# Altium schematic sheet PowerSupply.SchDoc(PowerSupply)
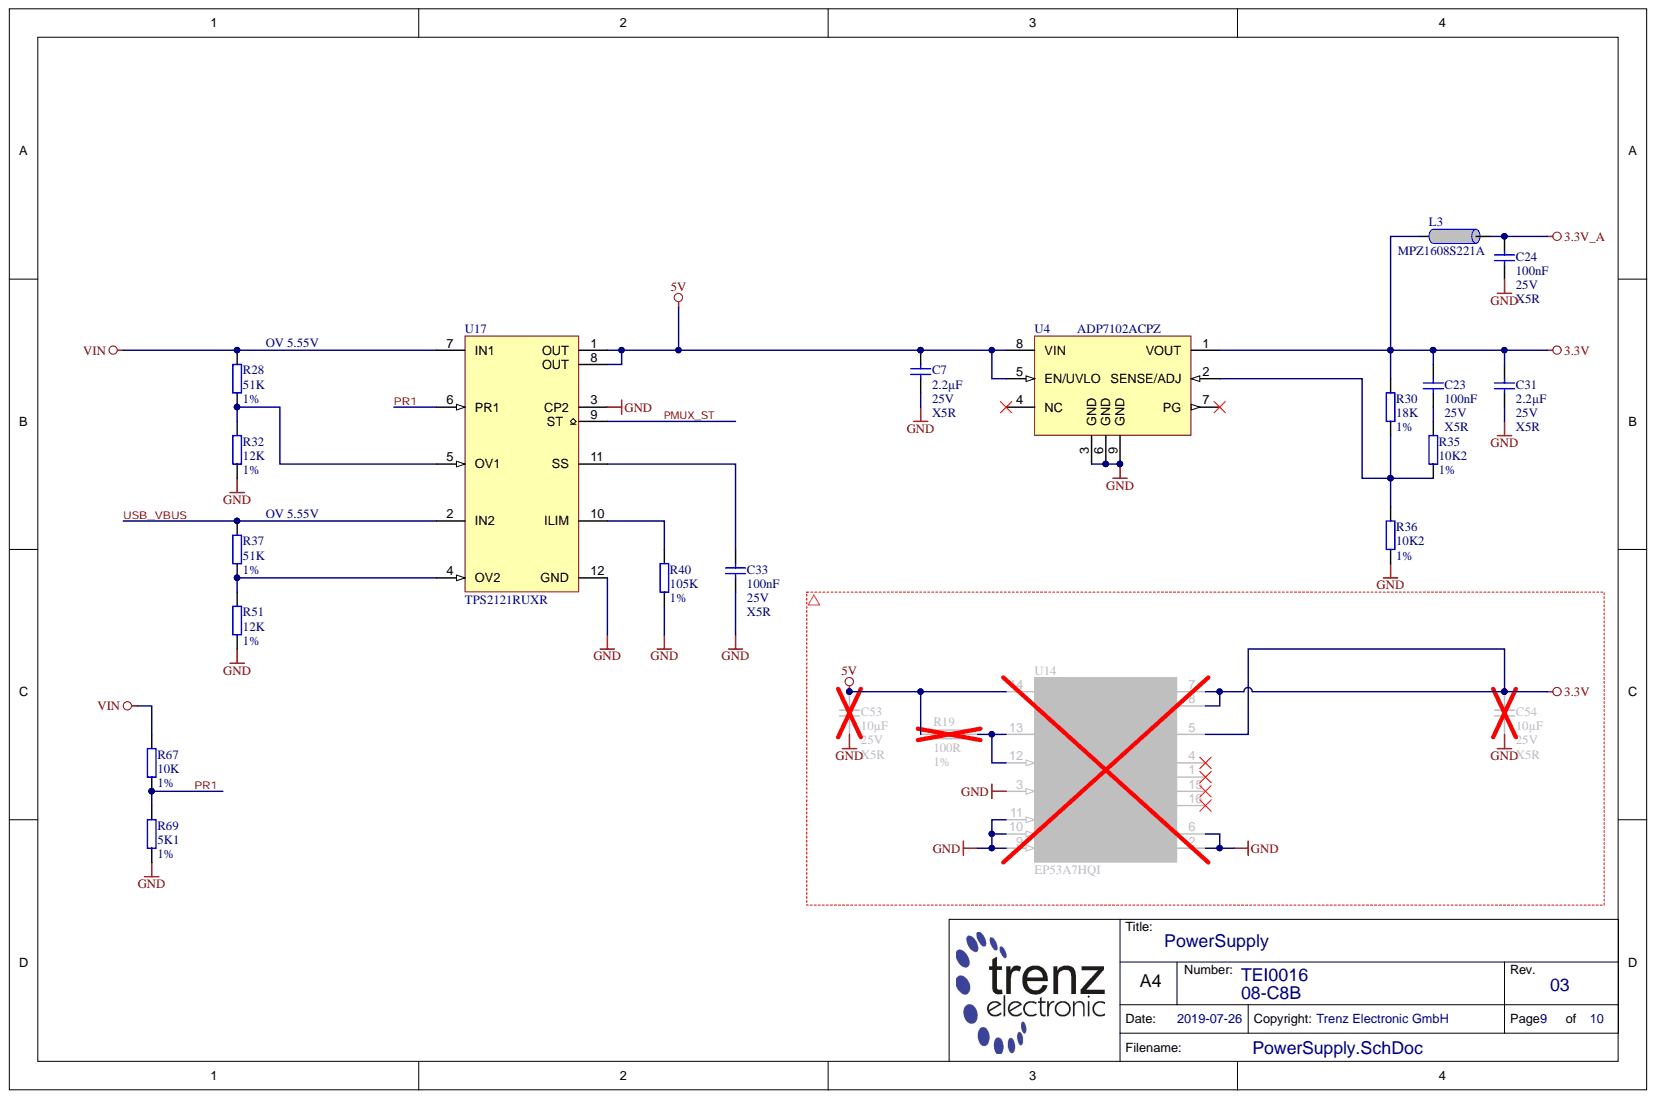
[diagram p0: PowerSupply.SchDoc]

## Parts
C7  2.2µF  Technology: X5R  Voltage: 25V  [CAPC1608X90N]
C23  100nF  Voltage: 25V  [CAPC1005X55N]
C24  100nF  Technology: X5R  Voltage: 25V  [CAPC1005X55N]
C31  2.2µF  Technology: X5R  Voltage: 25V  [CAPC1608X90N]
C33  100nF  Technology: X5R  Voltage: 25V  [CAPC1005X55N]
C53  10µF  Technology: X5R  Voltage: 25V  [CAPC2012X135N]
C54  10µF  Technology: X5R  Voltage: 25V  [CAPC2012X135N]
L3  MPZ1608S221A  [INDC1608X95N]
R19  100R  Tolerance: 1%  [RESC1005X40N]
R28  51K  Tolerance: 1%  [RESC1005X40N]
R30  18K  Tolerance: 1%  [RESC1005X40N]
R32  12K  Tolerance: 1%  [RESC1005X40N]
R35  10K2  Tolerance: 1%  [RESC1005X40N]
R36  10K2  Tolerance: 1%  [RESC1005X40N]
R37  51K  Tolerance: 1%  [RESC1005X40N]
R40  105K  Tolerance: 1%  [RESC1005X40N]
R51  12K  Tolerance: 1%  [RESC1005X40N]
R67  10K  Tolerance: 1%  [RESC1005X40N]
R69  5K1  Tolerance: 1%  [RESC1005X40N]
U4  ADP7102ACPZ  [SON50P300X300X80-9N]
U14  EP53A7HQI  [EP53F8QI]
U17  TPS2121RUXR  [QFNP50P200X250X100-12N]

## Nets
3.3V: C23.1 C31.1 C54.1 L3.1 R30.1 U4.1(VOUT) U14.5(VSENSE) U14.7(VOUT) U14.8(VOUT)
3.3V_A: C24.1 L3.2
5V: C7.1 C53.1 R19.1 U4.5(EN/UVLO) U4.8(VIN) U14.14(PVIN) U17.1(OUT) U17.8(OUT)
GND: C7.2 C24.2 C31.2 C33.2 C53.2 C54.2 R32.2 R36.2 R40.2 R51.2 R69.2 U4.3(GND) U4.6(GND) U4.9(GND) U14.2(PGND) U14.3(LLM) U14.6(AGND) U14.9(VS2) U14.10(VS1) U14.11(VS0) U17.3(CP2) U17.12(GND)
VIN: R28.1 R67.1 U17.7(IN1)
PMUX_ST: U17.9(ST)
PR1: R67.2 R69.1 U17.6(PR1)
USB_VBUS: R37.1 U17.2(IN2)
NetC23_2: C23.2 R35.1
NetC33_1: C33.1 U17.11(SS)
NetR19_2: R19.2 U14.12(ENABLE) U14.13(AVIN)
NetR28_2: R28.2 R32.1 U17.5(OV1)
NetR30_2: R30.2 R35.2 R36.1 U4.2(SENSE/ADJ)
NetR37_2: R37.2 R51.1 U17.4(OV2)
NetR40_1: R40.1 U17.10(ILIM)

Not connected: U4 4(NC) 7(PG); U14 1(NC(SW)) 4(NC) 15(NC(SW)) 16(NC(SW))

## Library data of the parts
C7 C31 = 27910: KON 2.2uF 25V X5R ±10% SMD BF 1608 (0603); Database Table Name: C_1608_0603; Mixed: SMT; Temperature-Range: -55/+85; Value: 2.2u; AN: 27910; Case: 1608 (0603); Component Kind: Standard; Library Name: TE.DbLib; Tolerance: ±10%
C23 C24 C33 = 22472: KON 100nF 25V X5R ±10% SMD BF 1005 (0402); Database Table Name: C_1005_0402; Mixed: SMT; Preferred: Yes; Temperature-Range: -55/+85; Value: 100n; AN: 22472; Case: 1005 (0402); Component Kind: Standard; Library Name: TE.DbLib
  C23: Technology: X5R
C53 C54 = 25567: KON 10µF 25V X5R 10% SMD BF 2012 (0805); Database Table Name: C_2012_0805; Mixed: SMT; Temperature-Range: -55/+85; Value: 10u; AN: 25567; Case: 2012 (0805); Component Kind: Standard; Library Name: TE.DbLib; Tolerance: 10%
L3 = 22718: Ferritdurchführung MPZ1608S221A; Database Table Name: L_1608_0603; Mixed: SMT; Preferred: Yes; Value: 220 OHm @ 100MHz; AN: 22718; Case: 1608 (0603); Component Kind: Standard; H-ART: MPZ1608S221A; Library Name: TE.DbLib; Trenz-Stock: Yes; HelpURL: B:\AD\adlib\datasheet\MPZ1608
R19 = 22507: WID SMD 100R 1% 0,063W  BF 1005 (0402); Database Table Name: R_1005_0402; H-ART: RC0402FR-13100RL; Mixed: SMT; Preferred: Yes; Value: 100; AN: 22507; Case: 1005 (0402); Component Kind: Standard; Library Name: TE.DbLib; Technology: TK100
R28 R37 = 24466: WID SMD 51K 1% 0,063W; Database Table Name: R_1005_0402; Mixed: SMT; AN: 24466; Case: 1005 (0402); Component Kind: Standard; Library Name: TE.DbLib; Technology: TK100; Value: 51K
R30 = 24875: WID SMD 18K 1% 0,063W  BF 1005 (0402); Database Table Name: R_1005_0402; Mixed: SMT; Preferred: Yes; AN: 24875; Case: 1005 (0402); Component Kind: Standard; Library Name: TE.DbLib; Technology: TK100; Value: 18K
R32 R51 = 22598: WID SMD 12K 1% 0,063W  BF 1005 (0402); Database Table Name: R_1005_0402; Mixed: SMT; Preferred: Yes; AN: 22598; Case: 1005 (0402); Component Kind: Standard; Library Name: TE.DbLib; Technology: TK100; Value: 12K
R35 R36 = 28019: WID SMD 10K2 1% 0,063W BF 1005 (0402); Database Table Name: R_1005_0402; H-ART: MCWR04X1022FTL; Mixed: SMT; Value: 10.2K; AN: 28019; Case: 1005 (0402); Component Kind: Standard; Library Name: TE.DbLib; Technology: TK100
R40 = 26467: WID SMD 105K 1% 0,063W; Database Table Name: R_1005_0402; Mixed: SMT; Preferred: Yes; AN: 26467; Case: 1005 (0402); Component Kind: Standard; Library Name: TE.DbLib; Technology: TK100; Value: 105K
R67 = 22750: WID SMD 10K 1% 0,063W BF 1005 (0402); Database Table Name: R_1005_0402; H-ART: RC0402FR-0710KL; Mixed: SMT; Preferred: Yes; AN: 22750; Case: 1005 (0402); Component Kind: Standard; Library Name: TE.DbLib; Technology: TK100; Value: 10K
R69 = 23125: WID SMD 5K1 1% 0,063W BF 1005 (0402); Database Table Name: R_1005_0402; Mixed: SMT; Value: 5.1K; AN: 23125; Case: 1005 (0402); Component Kind: Standard; Library Name: TE.DbLib; Technology: TK100
U4 = 24611: ADP7102ACPZ-R7; Database Table Name: LREG; Mixed: SMT; Trenz-Stock: Yes; AN: 24611; Case: DFN8; Component Kind: Standard; H-ART: ADP7102ACPZ-R7; Library Name: TE.DbLib; HelpURL: B:\AD\adlib\datasheet\ADP7102
U14 = 27996: Database Table Name: DCDC; Mixed: SMT; Preferred: Yes; Temperature-Range: -40/+85; AN: 27996; Case: QFN16; Component Kind: Standard; Description: EP53A7HQI; H-ART: EP53A7HQI; Library Name: TE.DbLib; Trenz-Stock: Yes; HelpURL: B:\AD\adlib\datasheet\EP53A7HQI
U17 = 29703: Database Table Name: SUPERVISOR; Mixed: SMT; Temperature-Range: -40/+125 (Tj); AN: 29703; Case: QFN12; Component Kind: Standard; Description: TPS2121RUXR; H-ART: TPS2121RUXR; Library Name: TE.DbLib; Storage-Temperature: -65/+150(Abs); HelpURL: b:\AD\adlib\datasheet\TPS212x\
